# Altium schematic sheet BMS.SchDoc(BMS)
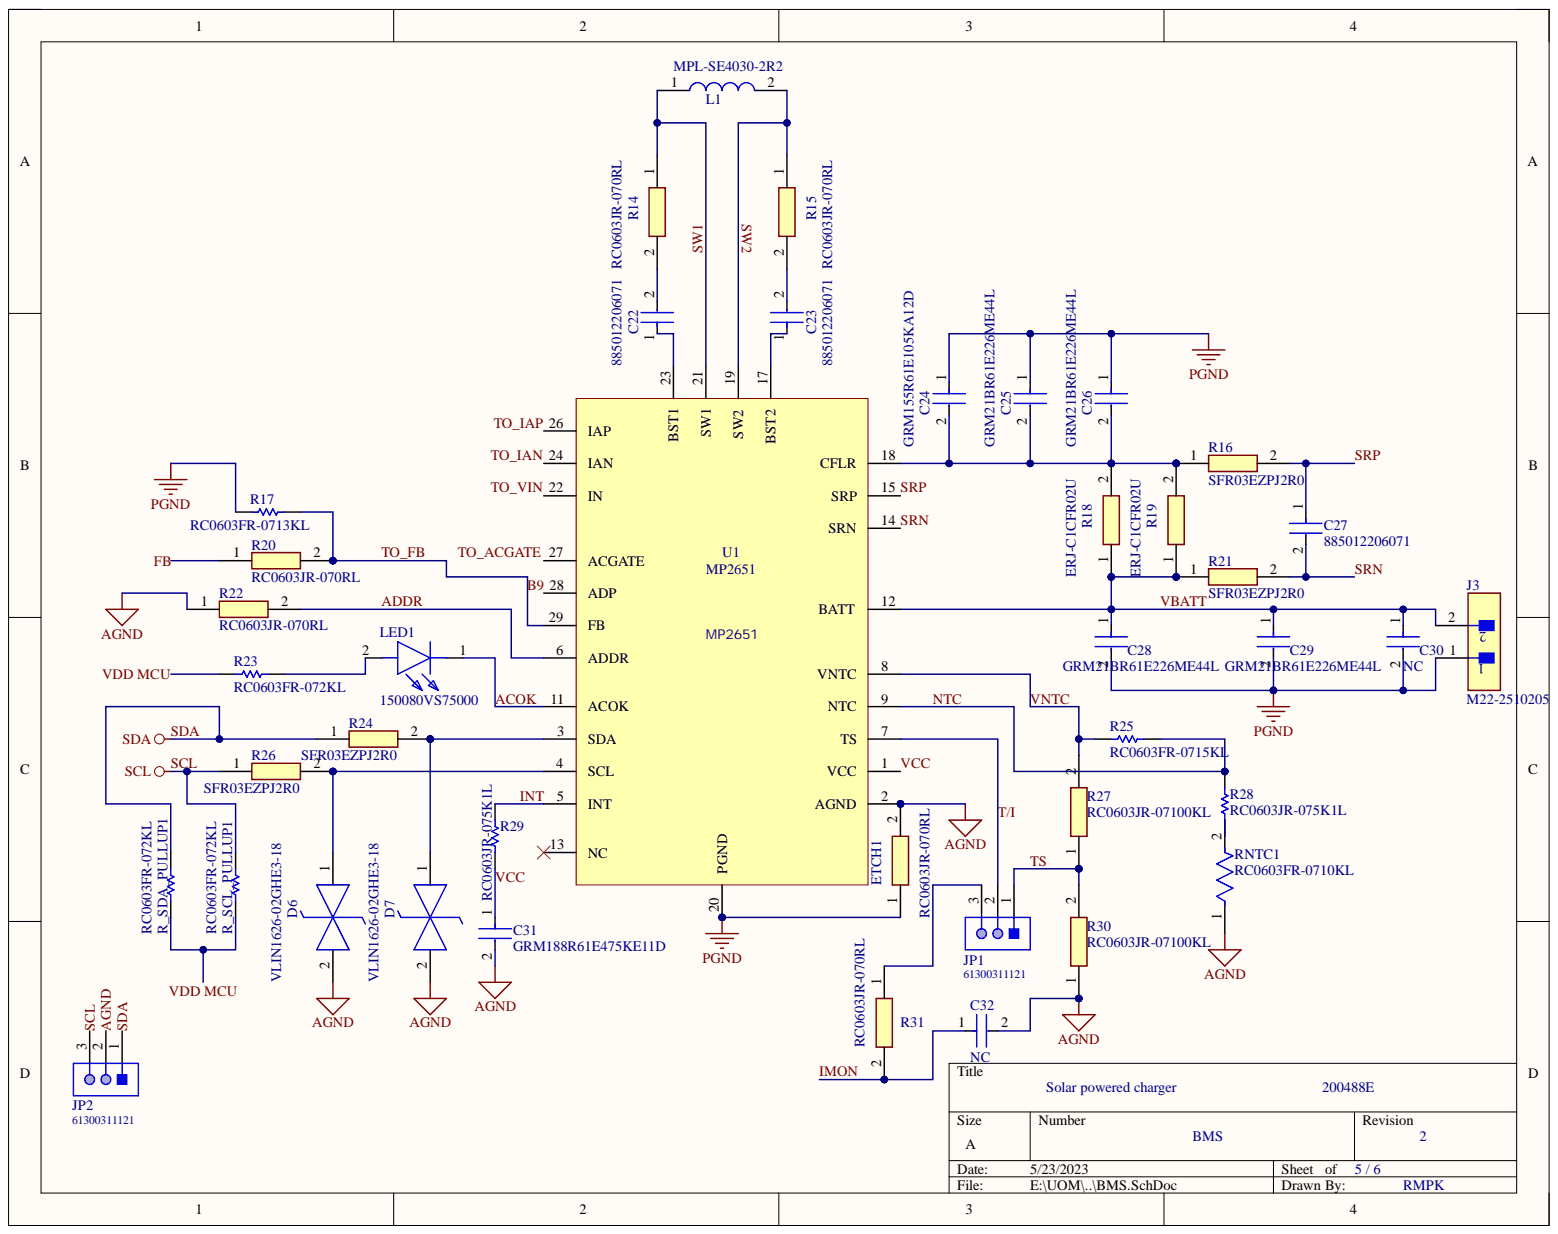
[diagram p0: BMS.SchDoc]

## Parts
C22  885012206071  [FP-885012206071-MFG]
C23  885012206071  [FP-885012206071-MFG]
C24  GRM155R61E105KA12D  [FP-GRM155-0_05-IPC_B]
C25  GRM21BR61E226ME44L  [FP-GRM21B-0_2-e0_2_0_7-IPC_B]
C26  GRM21BR61E226ME44L  [FP-GRM21B-0_2-e0_2_0_7-IPC_B]
C27  885012206071  [FP-885012206071-MFG]
C28  GRM21BR61E226ME44L  [FP-GRM21B-0_2-e0_2_0_7-IPC_B]
C29  GRM21BR61E226ME44L  [FP-GRM21B-0_2-e0_2_0_7-IPC_B]
C30  NC  [FP-GRM21B-0_2-e0_2_0_7-IPC_B]
C31  GRM188R61E475KE11D  [FP-GRM188-0_15-IPC_C]
C32  NC  [FP-GRM21B-0_2-e0_2_0_7-IPC_B]
D6  VLIN1626-02GHE3-18  [SOD2713X115N]
D7  VLIN1626-02GHE3-18  [SOD2713X115N]
ETCH1  RC0603JR-070RL  [RESC1608X55N]
J3  M22-2510205  [FP-M22-2510205-MFG]
JP1  61300311121  [61300311121]
JP2  61300311121  [61300311121]
L1  MPL-SE4030-2R2  [MPLSE40302R2]
LED1  150080VS75000  [LEDC2012X80N]
R14  RC0603JR-070RL  [RESC1608X55N]
R15  RC0603JR-070RL  [RESC1608X55N]
R16  SFR03EZPJ2R0  [RESC1608X55N]
R17  RC0603FR-0713KL  [RESC1608X55X25LL10T15]
R18  ERJ-C1CFR02U  [ERJC1]
R19  ERJ-C1CFR02U  [ERJC1]
R20  RC0603JR-070RL  [RESC1608X55N]
R21  SFR03EZPJ2R0  [RESC1608X55N]
R22  RC0603JR-070RL  [RESC1608X55N]
R23  RC0603FR-072KL  [RESC1608X55X25LL10T15]
R24  SFR03EZPJ2R0  [RESC1608X55N]
R25  RC0603FR-0715KL  [RESC1608X55X25LL10T15]
R26  SFR03EZPJ2R0  [RESC1608X55N]
R27  RC0603JR-07100KL  [RESC1608X55N]
R28  RC0603JR-075K1L  [RESC1608X55X25LL10T15]
R29  RC0603JR-075K1L  [RESC1608X55X25LL10T15]
R30  RC0603JR-07100KL  [RESC1608X55N]
R31  RC0603JR-070RL  [RESC1608X55N]
R_SCL_PULLUP1  RC0603FR-072KL  [RESC1608X55X25LL10T15]
R_SDA_PULLUP1  RC0603FR-072KL  [RESC1608X55X25LL10T15]
RNTC1  RC0603FR-0710KL  [FP-RC0603-0_55-IPC_C]
U1  MP2651  [MP2651]

## Nets
PGND: C24.1 C25.1 C26.1 C28.2 C29.2 C30.2 ETCH1.1 J3.1 R17.1 U1.20(PGND)
ACOK: LED1.1 U1.11(ACOK)
ADDR: R22.2 U1.6(ADDR)
FB: R20.1
GND: C31.2 C32.2 D6.2 D7.2 ETCH1.2 JP2.2 R22.1 R30.1 RNTC1.1 U1.2(AGND)
IMON: C32.1 R31.2
INT: R29.2 U1.5(INT)
NTC: R25.2 R28.2 U1.9(NTC)
SCL: JP2.3 R26.1 R_SCL_PULLUP1.2
SDA: JP2.1 R24.1 R_SDA_PULLUP1.2
SRN: C27.2 R21.2 U1.14(SRN)
SRP: C27.1 R16.2 U1.15(SRP)
SW1: L1.1 R14.1 U1.21(SW1)
SW2: L1.2 R15.1 U1.19(SW2)
T/I: JP1.2 U1.7(TS)
TO_ACGATE: U1.27(ACGATE)
TO_ADP: U1.28(ADP)
TO_FB: R17.2 R20.2 U1.29(FB)
TO_IAN: U1.24(IAN)
TO_IAP: U1.26(IAP)
TO_VIN: U1.22(IN)
TS: JP1.1 R27.1 R30.2
VBATT: C28.1 C29.1 C30.1 J3.2 R18.1 R19.1 R21.1 U1.12(BATT)
VCC: C31.1 R29.1 U1.1(VCC)
VDD MCU: R23.1 R_SCL_PULLUP1.1 R_SDA_PULLUP1.1
VNTC: R25.1 R27.2 U1.8(VNTC)
NetC22_1: C22.1 U1.23(BST1)
NetC22_2: C22.2 R14.2
NetC23_1: C23.1 U1.17(BST2)
NetC23_2: C23.2 R15.2
NetC24_2: C24.2 C25.2 C26.2 R16.1 R18.2 R19.2 U1.18(CFLR)
NetD6_1: D6.1 R26.2 U1.4(SCL)
NetD7_1: D7.1 R24.2 U1.3(SDA)
NetJP1_3: JP1.3 R31.1
NetLED1_2: LED1.2 R23.2
NetR28_1: R28.1 RNTC1.2

Not connected: U1 13(NC)

## Library data of the parts
C22 C23 C27 = CMP-207839-000033-1: CAP CER 0.1UF 25V X7R 0603; Capacitance: 100nF; Tolerance: 10%; Case Code (Imperial): 0603; Case Code (Metric): 1608; Case/Package: 0603; Component Kind: Standard; Dielectric: X7R; Length: 0.063inch; Library Name: Altium Content Vault; Max Operating Temperature: 125°C; Min Operating Temperature: -55°C; Mount: Surface Mount; Packaging: Cut Tape; RoHS Compliant: Yes; Series: WCAP-CSGP; Thickness: 0.037inch; Voltage Rating: 25V; Voltage Rating (DC): 25V; Width: 0.031inch
C24 = CMP-2008-04581-2: Chip Multilayer Ceramic Capacitors for General Purpose, 0402, 1.0uF, X5R, 15%, 10%, 25V; Capacitance: 1uF; Case Code (Imperial): 0402; Case Code (Metric): 1005; Case/Package: 0402; Component Kind: Standard; Depth: 0.5mm; Dielectric: X5R; Height: 0.5mm; Lead Free: Lead Free; Length: 1mm; Library Name: Altium Content Vault; Material: Ceramic; Max Operating Temperature: 85°C; Min Operating Temperature: -55°C; Mount: Surface Mount; Package Quantity: 10000; Packaging: Tape and Reel; REACH SVHC: No SVHC; Termination: SMD/SMT; Thickness: 0.022inch; Tolerance: 10%; Voltage: 25V; Voltage Rating: 25V; Voltage Rating (DC): 25V; Weight: 0.000212oz; Width: 0.5mm
C25 C26 C28-C30 C32 = CMP-2007-03222-3: Chip Multilayer Ceramic Capacitors for General Purpose, 0805, 22uF, X5R, 15%, 20%, 25V; Capacitance: 22uF; Case Code (Imperial): 0805; Case Code (Metric): 2012; Case/Package: 0805; Component Kind: Standard; Depth: 1.25mm; Dielectric: X5R; Height: 1.25mm; Lead Free: Lead Free; Length: 2mm; Library Name: Altium Content Vault; Material: Ceramic; Max Operating Temperature: 85°C; Min Operating Temperature: -55°C; Mount: Surface Mount; Package Quantity: 3000; Packaging: Tape and Reel; REACH SVHC: No SVHC; Resistor Type: Ceramic; Termination: SMD/SMT; Thickness: 0.057inch; Tolerance: 20%; Voltage: 25V; Voltage Rating: 25V; Voltage Rating (DC): 25V; Weight: 0.000335oz; Width: 1.25mm
C31 = CMP-2006-02523-2: Chip Multilayer Ceramic Capacitors for General Purpose, 0603, 4.7uF, X5R, 15%, 10%, 25V; Capacitance: 4.7uF; Case Code (Imperial): 0603; Case Code (Metric): 1608; Case/Package: 0603; Component Kind: Standard; Depth: 0.8mm; Dielectric: X5R; Height: 0.8mm; Lead Free: Lead Free; Length: 1.6mm; Library Name: Altium Content Vault; Material: Ceramic; Max Operating Temperature: 85°C; Min Operating Temperature: -55°C; Mount: Surface Mount; Package Quantity: 4000; Packaging: Cut Tape; REACH SVHC: No SVHC; Thickness: 0.031inch; Tolerance: 10%; Voltage: 25V; Voltage Rating: 25V; Voltage Rating (DC): 25V; Width: 0.031inch
D6 D7 = VLIN1626-02GHE3-18: Diode ESD (Bi-directional); Manufacturer_Name: Vishay; Component Kind: Standard; Datasheet Link: https://www.mouser.com/datasheet/2/427/vlin1626_02g-1767732.pdf; Height: 1.15mm; Manufacturer_Part_Number: VLIN1626-02GHE3-18; Mouser Part Number: 78-VLIN1626-02GHE318; Mouser Price/Stock: https://www.mouser.co.uk/ProductDetail/Vishay-Semiconductors/VLIN1626-02GHE3-18?qs=nIjV%252BW72yUtWws5A0kqvDg%3D%3D
ETCH1 R14 R15 R20 R22 R31 = RC0603JR-070RL: Resistor; Manufacturer_Name: YAGEO; Arrow Part Number: RC0603JR-070RL; Arrow Price/Stock: https://www.arrow.com/en/products/rc0603jr-070rl/yageo?region=europe; Component Kind: Standard; Datasheet Link: https://componentsearchengine.com/Datasheets/2/RC0603JR-070RL.pdf; Height: 0.55mm; Manufacturer_Part_Number: RC0603JR-070RL; Mouser Part Number: 603-RC0603JR-070RL; Mouser Price/Stock: https://www.mouser.co.uk/ProductDetail/YAGEO/RC0603JR-070RL?qs=2cAdsCoAWRGWyPcuXgGiXQ%3D%3D
J3 = CMP-15831-000005-1: CONN HEADER VERT 2POS 2MM; Manufacturer: Harwin; Conn Gender: Male; Conn Orientation: Vertical; Current Rating: 2A; Number Of Contacts: 2; Automotive: No; Category: Conn; Component Kind: Standard; ComponentLink2Description: Datasheet; ComponentLink2URL: https://cdn.harwin.com/pdfs/C018XX_M22_Series_Connectors.pdf; Contact Material: Gold,Nickel; Device Class L1: Connectors; Device Class L2: Headers and Wire Housings; Device Class L3: unset; Digikey Description: CONN HEADER VERT 2POS 2MM; Footprint Url: https://cdn.harwin.com/pdfs/M22-251.pdf; Height: 5.45mm; Lead Free: Yes; Library Name: Altium Content Vault; Manufacturer Part Number: M22-2510205; Mouser Description: Headers & Wire Housings 2 PIN SIL VERTICAL GOLD PIN HEADER; Number Of Rows: 1; Octopart: https://octopart.com/m22-2510205-harwin-2538221; Package: 0; Pitch: 2mm; RoHS: TRUE; Temperature: -40°C to +105°C; Temperature Range High: +105°C; Temperature Range Low: -40°C
JP1 JP2 = CMP-1502-01062-1: THT Vertical Pin Header WR-PHD, Pitch 2.54 mm, Single Row, 3 pins; Manufacturer: Wurth Elektronik; Contact Resistance: 20mOhm; Gender: Male; Pin Number: 3; Pitch: 2.54mm; Rated Current: 3A; Withstanding Voltage: 500VAC; Working Voltage: 250VAC; Component Kind: Standard; Datasheet URL: https://katalog.we-online.de/em/datasheet/6130xx11121.pdf; Library Name: Altium Content Vault; Manufacturer URL: http://www.we-online.de; Mounting Technology: THT; Operating Temperature: -40to105degC; Orientation: Vertical; Package Reference: 61300311121; Packaging: Bag; Part Number: 61300311121; Row Number: Single; Publisher: WurthElektronik
L1 = MPL-SE4030-2R2: Inductor; Manufacturer_Name: Monolithic Power Systems (MPS); Component Kind: Standard; Datasheet Link: https://www.monolithicpower.com/en/documentview/productdocument/index/version/2/document_type/Datasheet/lang/en/sku/MPL-SE4030-2R2/document_id/5900/; Height: 3mm; Manufacturer_Part_Number: MPL-SE4030-2R2; Mouser Part Number: 946-MPL-SE4030-2R2; Mouser Price/Stock: https://www.mouser.co.uk/ProductDetail/Monolithic-Power-Systems-MPS/MPL-SE4030-2R2?qs=sPbYRqrBIVkUqvyiguqldQ%3D%3D
LED1 = 150080VS75000: LED; Manufacturer_Name: Wurth Elektronik; Component Kind: Standard; Datasheet Link: http://katalog.we-online.com/led/datasheet/150080VS75000.pdf; Height: 0.8mm; Manufacturer_Part_Number: 150080VS75000; Mouser Part Number: 710-150080VS75000; Mouser Price/Stock: https://www.mouser.co.uk/ProductDetail/Wurth-Elektronik/150080VS75000?qs=LlUlMxKIyB0tGHJmO6%252B0ug%3D%3D
R16 R21 R24 R26 = SFR03EZPJ2R0: Resistor; Manufacturer_Name: ROHM Semiconductor; Component Kind: Standard; Datasheet Link: https://www.mouser.com/datasheet/2/348/ROHM_S_A0009648217_1-2563033.pdf; Height: 0.55mm; Manufacturer_Part_Number: SFR03EZPJ2R0
R17 = CMP-2000-06927-1: Chip Resistor, 13 KOhm, +/- 1%, 0.1 W, -55 to 155 degC, 0603 (1608 Metric), RoHS, Tape and Reel; Manufacturer: Yageo; Power Rating: 100mW; Resistance: 13kOhms; Temperature Coefficient: 100PPM/C; Voltage Rating: 50V; Case Code - in: 0603; Case Code - mm: 1608; Component Kind: Standard; Datasheet URL: http://www.yageo.com/documents/recent/PYu-RC_Group_51_RoHS_L_7.pdf; Datasheet Version: Rev. 7, 05/2017; Library Name: Altium Content Vault; Manufacturer URL: http://www.yageo.com/; Mounting Technology: SurfaceMount; Operating Temperature Range: -55Cto+155C; Package Description: 2-Pin Surface Mount Device, Body 1.6 x 0.8 mm; Package Reference: 0603; Packaging: Reel; Product: ThickFilmResistorsGeneralPurpose; Series: RC; Termination Style: SMD/SMT; Tolerance: 1%
R18 R19 = ERJ-C1CFR02U: Resistor; Manufacturer_Name: Panasonic; Component Kind: Standard; Datasheet Link: https://industrial.panasonic.com/cdbs/www-data/pdf/RDP0000/AOA0000C340.pdf; Height: 0.75mm; Manufacturer_Part_Number: ERJ-C1CFR02U; Mouser Part Number: 667-ERJ-C1CFR02U; Mouser Price/Stock: https://www.mouser.co.uk/ProductDetail/Panasonic/ERJ-C1CFR02U?qs=Z3CaLxJiOJL4jODaXLT4ow%3D%3D
R23 R_SCL_PULLUP1 R_SDA_PULLUP1 = CMP-2000-06937-1: Chip Resistor, 2 KOhm, +/- 1%, 50 V, -55 to 155 degC, 0603 (1608 Metric), RoHS, Tape and Reel; Manufacturer: Yageo; Catalog Drawings: ThickFilmResistorTopThickFilmResistorSide; EDA / CAD Models: DownloadfromAcceleratedDesigns; HTML Datasheet: RCSeries,LSuffixDatasheet.html; Kits: PHH4-KIT-ND-RESKIT1K-9.76K1/10W5700PCS; Online Catalog: RC0603Series; Other Names: 311-2.00KHRCT; PCN Other: RC/AC0603/0805/120622/Jan/2013; Power (Watts): 0.1W,1/10W; Resistance (Ohms): 2k; Temperature Coefficient: ±100ppm/°C; Category: Resistors; Component Kind: Standard; Composition: ThickFilm; Datasheet URL: http://www.yageo.com/documents/recent/PYu-RC_Group_51_RoHS_L_7.pdf; Datasheet Version: Rev. 7, 05/2017; Family: ChipResistor-SurfaceMount; Features: MoistureResistant; Height: 0.022"(0.55mm); Library Name: Altium Content Vault; Manufacturer URL: http://www.yageo.com/; Mounting Technology: SurfaceMount; Number of Terminations: 2; Operating Temperature: -55°C~155°C; Package / Case: 0603(1608Metric); Package Description: 2-Pin Surface Mount Device, Body 1.6 x 0.8 mm; Package Reference: 0603; Packaging: CutTape(CT); Product Training Modules: ChipResistor; Series: RC; Size / Dimension: 0.063"Lx0.031"W(1.60mmx0.80mm); Standard Package: 1; Supplier Device Package: 0603; Tolerance: ±1%
R25 = CMP-1659-00032-1: Chip Resistor, 15 KOhm, +/- 1%, 0.1 W, -55 to 155 degC, 0603 (1608 Metric), RoHS, Tape and Reel; Component Kind: Standard; ComponentLink1Description: Manufacturer URL; ComponentLink1URL: http://www.yageo.com/; ComponentLink2Description: Datasheet; ComponentLink2URL: http://www.yageo.com/documents/recent/PYu-RC_Group_51_RoHS_L_04.pdf; DatasheetVersion: Rev. 4, 02/2016; Library Name: Altium Content Vault; PackageDescription: 2-Pin Surface Mount Device, Body 1.6 x 0.8 mm; PackageReference: 0603; RoHSCompliant: true
R27 R30 = RC0603JR-07100KL: Resistor; Manufacturer_Name: YAGEO; Arrow Part Number: RC0603JR-07100KL; Arrow Price/Stock: https://www.arrow.com/en/products/rc0603jr-07100kl/yageo?region=europe; Component Kind: Standard; Datasheet Link: https://www.yageo.com/upload/media/product/productsearch/datasheet/rchip/PYu-RC_Group_51_RoHS_L_12.pdf; Height: 0.55mm; Manufacturer_Part_Number: RC0603JR-07100KL; Mouser Part Number: 603-RC0603JR-07100KL; Mouser Price/Stock: https://www.mouser.co.uk/ProductDetail/YAGEO/RC0603JR-07100KL?qs=2cAdsCoAWRG9Rhqklpdeqg%3D%3D
R28 R29 = CMP-1012-00091-2: Chip Resistor, 5.1 KOhm, +/- 5%, 0.1 W, -55 to 155 degC, 0603 (1608 Metric), RoHS, Tape and Reel; Manufacturer: Yageo; Power Rating: 100mW; Resistance: 5.1kOhms; Temperature Coefficient: 100PPM/C; Voltage Rating: 50V; Case Code - in: 0603; Case Code - mm: 1608; Component Kind: Standard; Datasheet URL: http://www.yageo.com/documents/recent/PYu-RC_Group_51_RoHS_L_7.pdf; Datasheet Version: Rev. 7, 05/2017; Library Name: Altium Content Vault; Manufacturer URL: http://www.yageo.com/; Mounting Technology: SurfaceMount; Operating Temperature Range: -55Cto+155C; Package Description: 2-Pin Surface Mount Device, Body 1.6 x 0.8 mm; Package Reference: 0603; Packaging: Reel; Product: ThickFilmResistorsGeneralPurpose; Series: RC; Termination Style: SMD/SMT; Tolerance: 5%
RNTC1 = CMP-2100-03664-2: RES 10K OHM 1% 1/10W 0603; Max Power Dissipation: 100mW; Resistance: 10kR; Temperature Coefficient: 100ppm/°C; Voltage Rating: 75V; Voltage Rating (DC): 50V; Case Code (Imperial): 0603; Case Code (Metric): 1608; Case/Package: 0603; Component Kind: Standard; Construction: Rectangular; Depth: 0.8mm; Features: Moisture Resistant; Height: 0.45mm; Lead Free: Lead Free; Lead Pitch: 0.3mm; Length: 1.6mm; Library Name: Altium Content Vault; Max Operating Temperature: 155°C; Military Standard: Not; Min Operating Temperature: -55°C; Number of Pins: 2; Number of Terminations: 2; Packaging: Tape and Reel; Power Rating: 100mW; Resistance Tolerance: 1%; Resistor Type: Thick Film; RoHS Compliant: Yes; Series: RC; Size Code: 0603; Termination: SMD/SMT; Tolerance: 1%; Weight: 7.1E-05oz; Width: 0.8mm
U1 = MP2651: MP2651 – BUCK-BOOST CHARGER FOR 1 TO 4 CELLS IN SERIES; Component Kind: Standard; Library Name: Schlib1.SchLib
